# Altium schematic sheet [08] - Voltage Regulator.SchDoc("[08] - Voltage Regulator")
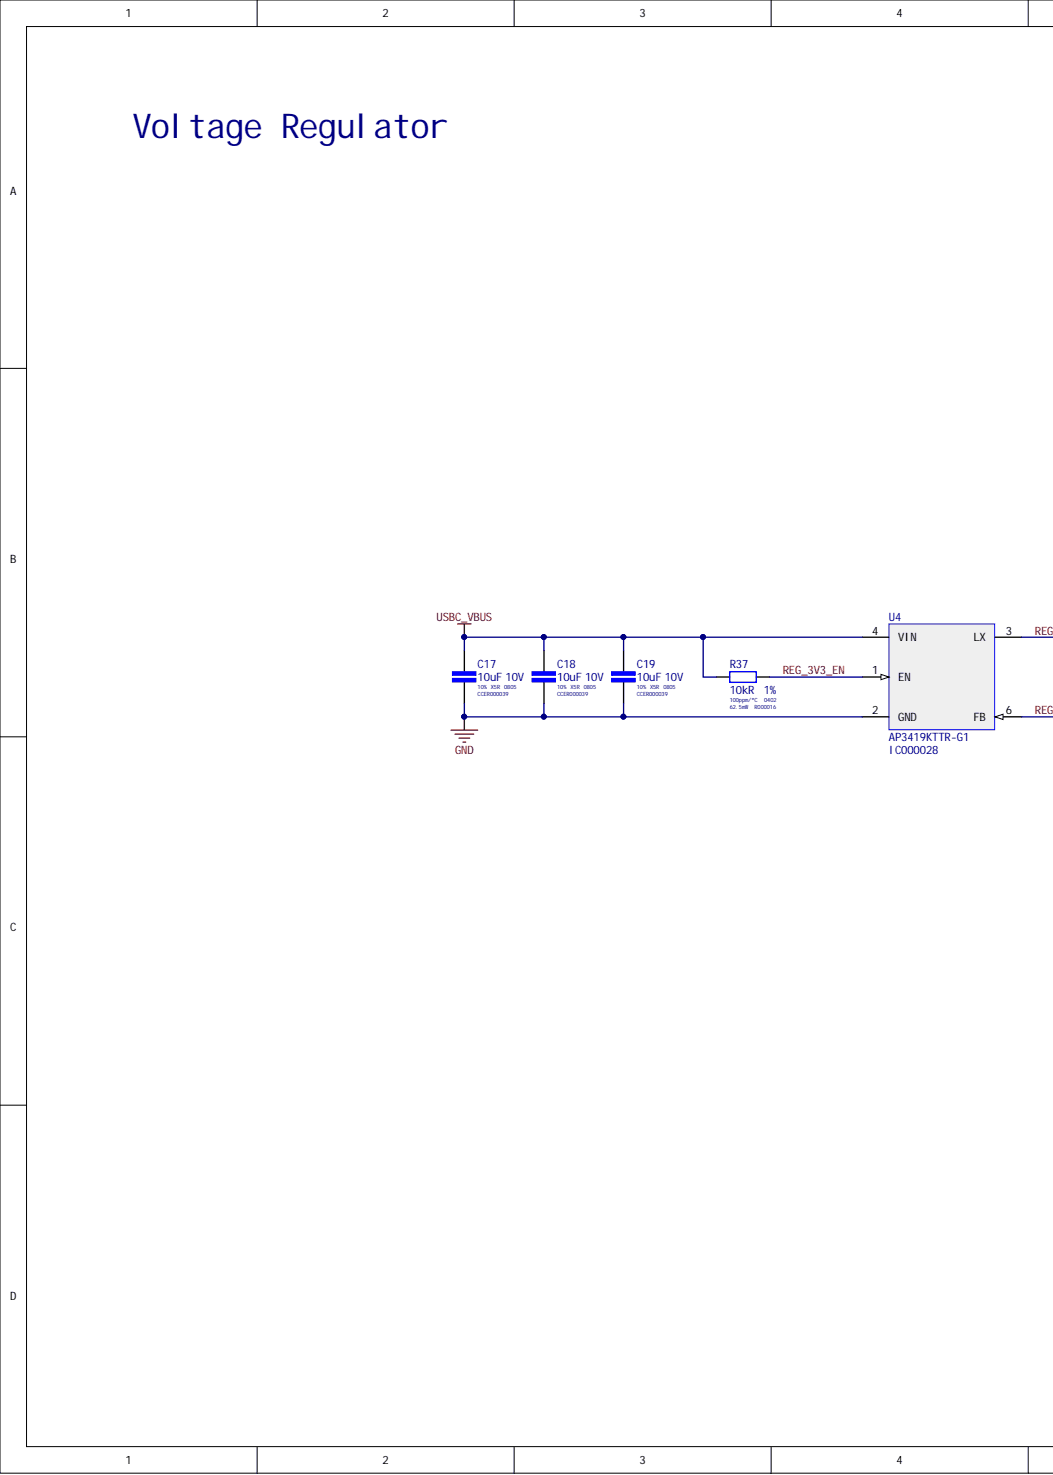
[diagram p0: [08] - Voltage Regulator.SchDoc, left]
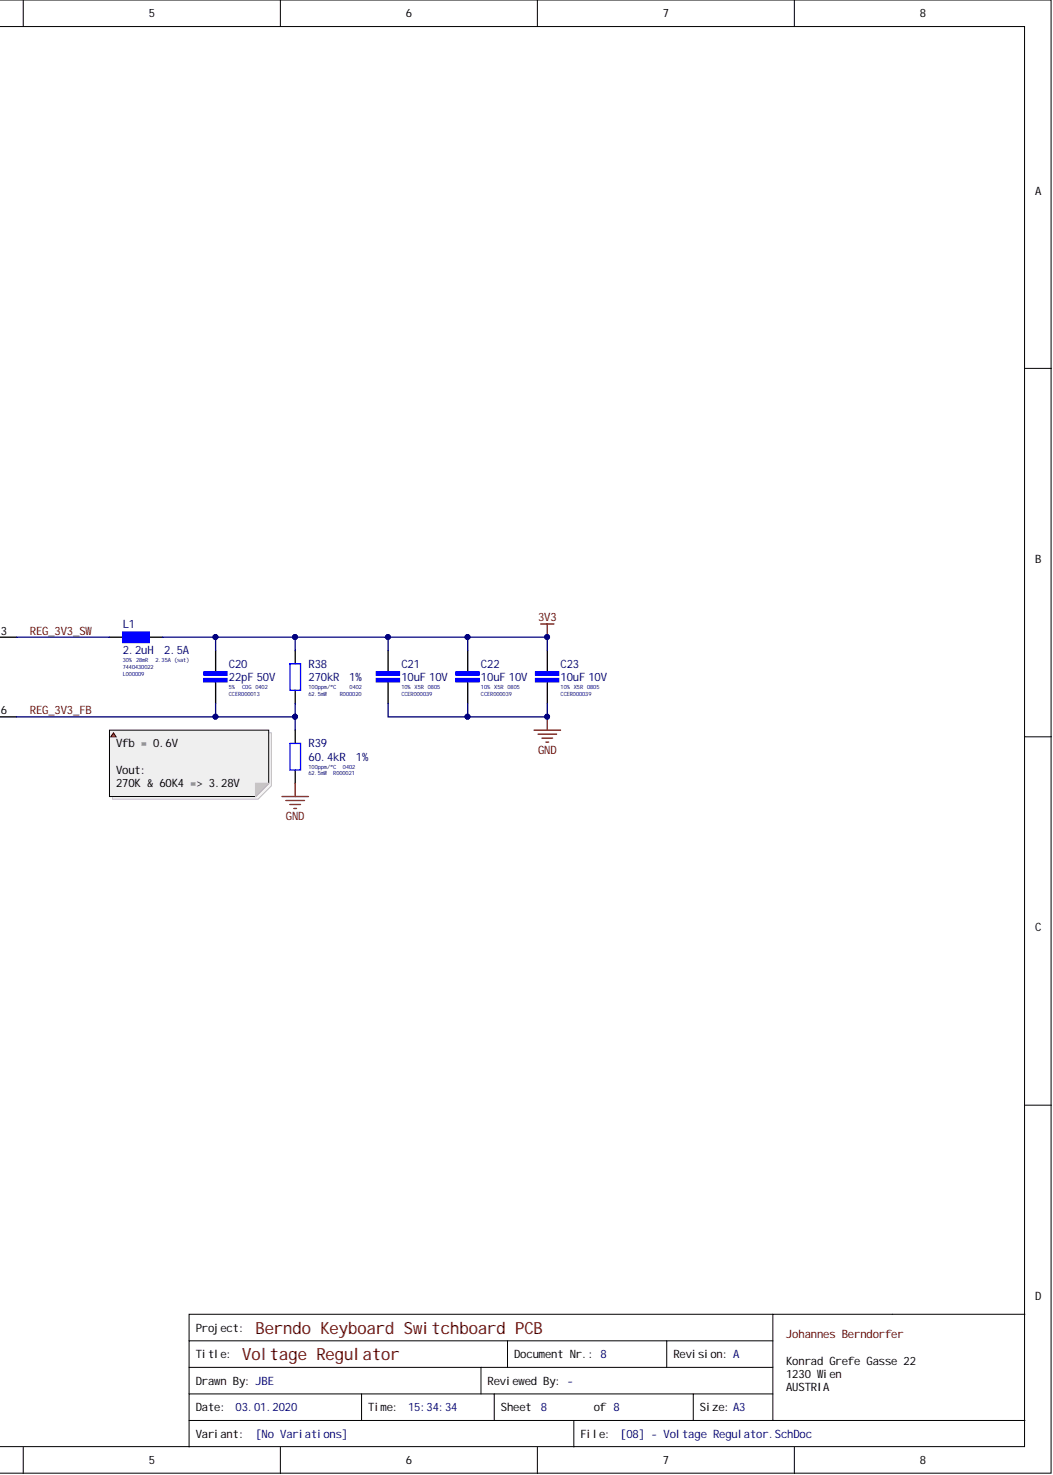
[diagram p1: [08] - Voltage Regulator.SchDoc, right]

## Parts
C17  10uF 10V  Tolerance: 10%  Internal Part Number: CCER000039  Dielectric: X5R  Capacitance: 10uF  [CAP-SMD-0805-1.35MM-IPC-MED]
C18  10uF 10V  Voltage Rating: 10V  Tolerance: 10%  Internal Part Number: CCER000039  Dielectric: X5R  Case Code (Imperial): 0805  Capacitance: 10uF  [CAP-SMD-0805-1.35MM-IPC-MED]
C19  10uF 10V  Voltage Rating: 10V  Tolerance: 10%  Internal Part Number: CCER000039  Dielectric: X5R  Case Code (Imperial): 0805  Capacitance: 10uF  [CAP-SMD-0805-1.35MM-IPC-MED]
C20  22pF 50V  Voltage Rating: 50V  Tolerance: 5%  Internal Part Number: CCER000013  Dielectric: C0G  Case Code (Imperial): 0402  Capacitance: 22pF  [CAP-SMD-0402-0.55MM-IPC-MED]
C21  10uF 10V  Voltage Rating: 10V  Tolerance: 10%  Internal Part Number: CCER000039  Dielectric: X5R  Capacitance: 10uF  [CAP-SMD-0805-1.35MM-IPC-MED]
C22  10uF 10V  Voltage Rating: 10V  Tolerance: 10%  Internal Part Number: CCER000039  Dielectric: X5R  Case Code (Imperial): 0805  Capacitance: 10uF  [CAP-SMD-0805-1.35MM-IPC-MED]
C23  10uF 10V  Voltage Rating: 10V  Tolerance: 10%  Internal Part Number: CCER000039  Dielectric: X5R  Case Code (Imperial): 0805  Capacitance: 10uF  [CAP-SMD-0805-1.35MM-IPC-MED]
L1  7440430022  Tolerance: 30%  Series Resistance: 28mR  RMS Current (Irms): 2.5A  Internal Part Number: L000009  Inductance: 2.2uH  [IND-SMD-7440430022-3MM]
R37  10kR 1%  Tolerance: 1%  Temperature Coefficient: 100ppm/°C  Resistance: 10kR  Power Rating: 62.5mW  Internal Part Number: R000016  Case Code (Imperial): 0402  [RES-SMD-0402-0.4MM-IPC-MED]
R38  Voltage Rating: 50V  Temperature Coefficient: 100ppm/°C  Resistance: 270kR  Max Power Dissipation: 62.5mW  Case Code (Imperial): 0402  [RES-SMD-0402-0.4MM-IPC-MED]  (hidden comment: RC0402FR-07270KL)
R39  Temperature Coefficient: 100ppm/°C  Resistance: 60.4kR  Power Rating: 62.5mW  [RES-SMD-0402-0.4MM-IPC-MED]  (hidden comment: RC0402FR-0760K4L)
U4  AP3419KTTR-G1  Internal Part Number: IC000028  [SOT-23-6-H1MM-DIODES-INC]

## Nets
3V3: C20.1 C21.1 C22.1 C23.1 L1.2 R38.1
GND: C17.2 C18.2 C19.2 C21.2 C22.2 C23.2 R39.2 U4.2(GND)
USBC_VBUS: C17.1 C18.1 C19.1 R37.1 U4.4(VIN)
REG_3V3_EN: R37.2 U4.1(EN)
REG_3V3_FB: C20.2 R38.2 R39.1 U4.6(FB)
REG_3V3_SW: L1.1 U4.3(LX)

## Library data of the parts
C17-C19 C21-C23 = CL21A106KPFNNNG: CAP CER 10U 10V X5R 10% SMD 0805 85C SAMSUNG; Width: 0.049inch; Voltage: 10V; Thickness: 0.053inch; Termination: Wraparound; Supplier Part Number 1: 1276-6456-1-ND; Supplier 1: Digi-Key; Stock 1: 884042; RoHS: Yes; Radiation Hardening: No; Pricing 1: 1=0,14000, 10=0,10000, 100=0,04690, 500=0,03290, 1000=0,02724 (USD); Packaging: Tape and Reel; Package Quantity: 3000; Mount: Surface Mount; Min Operating Temperature: -55°C; Max Operating Temperature: 85°C; Manufacturer Part Number 1: CL21A106KPFNNNG; Manufacturer 1: Samsung; Library Name: Altium_Lib_Standard.IntLib; Length: 2mm; Lead Free: Lead Free; Height: 1.25mm; Depth: 1.25mm; ComponentLink2URL: https://datasheet.ciiva.com/26936/getdatasheetpartid-640497-26936900.pdf?src-supplier=Verical; ComponentLink2Description: https://datasheet.ciiva.com/26936/getdatasheetpartid-640497-26936900.pdf?src-supplier=Verical; ComponentLink1URL: https://datasheet.ciiva.com/16428/cl21a106kpfnnng-16428896.pdf?src-supplier=Future+Electronics; ComponentLink1Description: https://datasheet.ciiva.com/16428/cl21a106kpfnnng-16428896.pdf?src-supplier=Future+Electronics; Component Kind: Standard; Case Code (Metric): 2012; Case/Package: 0805
  C17: Voltage Rating: 10V; Case Code (Imperial): 0805
  C21: Case Code (Imperial): 0805
C20 = CL05C220JB5NNNC: CAP CER 22P 50V C0G 5% SMD 0402 125C SAMSUNG; Temperature Coefficient: 300ppm/°C; Material: Ceramic; Width: 0.02inch; Voltage: 50V; Thickness: 0.022inch; Supplier Part Number 1: 1276-1116-1-ND; Supplier 1: Digi-Key; Stock 1: 1663917; RoHS: Yes; Radiation Hardening: No; Pricing 1: 1 = 0,10000, 10 = 0,03300, 100 = 0,01480, 500 = 0,01058, 1000 = 0,00831, 2500 = 0,00755, 5000 = 0,00695 (USD); Packaging: Tape and Reel; Package Quantity: 1; Mount: Surface Mount; Min Operating Temperature: -55°C; Max Operating Temperature: 125°C; Manufacturer Part Number: CL05C220JB5NNNC; Manufacturer: Samsung; Library Name: Altium_Lib_Standard.IntLib; Length: 1mm; Height: 0.5mm; Depth: 0.5mm; Contact Plating: Tin; ComponentLink4URL: https://datasheet.ciiva.com/26309/mlcc-catalogdownload2013-eng-26309032.pdf?src-supplier=Distrelec; ComponentLink4Description: https://datasheet.ciiva.com/26309/mlcc-catalogdownload2013-eng-26309032.pdf?src-supplier=Distrelec; ComponentLink3URL: https://datasheet.ciiva.com/16199/cl05b104kq5nnnc-16199080.pdf?src-supplier=Future+Electronics; ComponentLink3Description: https://datasheet.ciiva.com/16199/cl05b104kq5nnnc-16199080.pdf?src-supplier=Future+Electronics; ComponentLink2URL: https://datasheet.ciiva.com/29198/458663-da-01-en-vs-kondensator-0402-29198414.pdf?src-supplier=Conrad; ComponentLink2Description: https://datasheet.ciiva.com/29198/458663-da-01-en-vs-kondensator-0402-29198414.pdf?src-supplier=Conrad; ComponentLink1URL: https://datasheet.ciiva.com/15195/getdatasheetpartid-358448-15195679.pdf?src-supplier=Verical; ComponentLink1Description: https://datasheet.ciiva.com/15195/getdatasheetpartid-358448-15195679.pdf?src-supplier=Verical; Component Kind: Standard; Case Code (Metric): 1005; Case/Package: 0402
L1 = 7440430022: IND 2U2 2A5 28mR 30% SMD FERRITE WURTH; Manufacturer: Wurth Electronics; Test Frequency: 100kHz; Shielding: Shielded; Self Resonant Frequency: 100MHz; Saturation Current: 2.35A (sat); Width: 4.8mm; Weight: 0.009065oz; Termination: SMD/SMT; Supplier Part Number 1: 732-1095-1-ND; Supplier 1: Digi-Key; Stock 1: 3943; RoHS: Yes; REACH SVHC: Unknown; Pricing 1: 1 = 1,43000, 10 = 1,38900, 50 = 1,31900, 100 = 1,21500, 250 = 1,14600 (USD); Packaging: Tape and Reel; Package Quantity: 500; Mount: Surface Mount; Min Operating Temperature: -40°C; Max Operating Temperature: 125°C; Max DC Current: 2.5A; Manufacturer Part Number: 7440430022; Library Name: Altium_Lib_Standard.IntLib; Length: 4.8mm; Lead Free: Lead Free; Inductance Tolerance: 30%; Height - Seated (Max): 0.118inch; Height: 2.8mm; Depth: 4.8mm; DC Resistance (DCR): 28mR; Current Rating: 2.5A; Core Material: Ferrite; Composition: Wirewound; ComponentLink8URL: https://datasheet.ciiva.com/48690/001087187-da-01-ml-we-tpc-smd-speicherdrossel-7440430-de-en-48690886.pdf?src-supplier=Conrad; ComponentLink8Description: https://datasheet.ciiva.com/48690/001087187-da-01-ml-we-tpc-smd-speicherdrossel-7440430-de-en-48690886.pdf?src-supplier=Conrad; ComponentLink7URL: https://datasheet.ciiva.com/1075/7440430022-1075986.pdf?src-supplier=Digi-Key; ComponentLink7Description: https://datasheet.ciiva.com/1075/7440430022-1075986.pdf?src-supplier=Digi-Key; ComponentLink6URL: https://datasheet.ciiva.com/1075/7440430022-1075985.pdf?src-supplier=Digi-Key; ComponentLink6Description: https://datasheet.ciiva.com/1075/7440430022-1075985.pdf?src-supplier=Digi-Key; ComponentLink5URL: https://datasheet.ciiva.com/23782/1645803-23782392.pdf?src-supplier=Newark; ComponentLink5Description: https://datasheet.ciiva.com/23782/1645803-23782392.pdf?src-supplier=Newark; ComponentLink4URL: https://datasheet.ciiva.com/1075/7440430022-1075984.pdf?src-supplier=Digi-Key; ComponentLink4Description: https://datasheet.ciiva.com/1075/7440430022-1075984.pdf?src-supplier=Digi-Key; ComponentLink3URL: https://datasheet.ciiva.com/13277/7440430022-66848-13277005.pdf?src-supplier=Mouser; ComponentLink3Description: https://datasheet.ciiva.com/13277/7440430022-66848-13277005.pdf?src-supplier=Mouser; ComponentLink2URL: https://datasheet.ciiva.com/7754/1735426-7754427.pdf?src-supplier=Element14; ComponentLink2Description: https://datasheet.ciiva.com/7754/1735426-7754427.pdf?src-supplier=Element14; ComponentLink1URL: https://datasheet.ciiva.com/11803/0900766b80febc3e-11803289.pdf?src-supplier=RSComponents; ComponentLink1Description: https://datasheet.ciiva.com/11803/0900766b80febc3e-11803289.pdf?src-supplier=RSComponents; Component Kind: Standard; Case Code (Metric): 4848; Case Code (Imperial): 1919; Case/Package: 1919
R37 = RC0402FR-0710KL: RES 10K 1% 1/16W SMD 0402 YAEGO; Manufacturer: Yageo; Working Voltage: 50V; Width: 0.5mm; Voltage Rating (DC): 50V; Voltage Rating: 50V; Termination: SMD/SMT; Supplier Part Number 1: 311-10.0KLRCT-ND; Supplier 1: Digi-Key; Stock 1: 86154015; RoHS: Yes; REACH SVHC: No SVHC; Pricing 1: 1 = 0,10000, 10 = 0,01600, 100 = 0,00670, 1000 = 0,00301, 2500 = 0,00261, 5000 = 0,00215 (USD); Packaging: Tape and Reel; Package Quantity: 10000; Number of Terminations: 2; Number of Pins: 2; Min Operating Temperature: -55°C; Military Standard: Not; Max Operating Temperature: 155°C; Manufacturer Part Number: RC0402FR-0710KL; Library Name: Altium_Lib_Standard.IntLib; Length: 1mm; Lead Pitch: 0.2mm; Lead Free: Lead Free; Height: 0.32mm; Depth: 0.5mm; Composition: Thick Film; ComponentLink13URL: https://datasheet.ciiva.com/17070/pyu-rc0402-51-rohs-l-6-r-17070335.pdf?src-supplier=Future+Electronics; ComponentLink13Description: https://datasheet.ciiva.com/17070/pyu-rc0402-51-rohs-l-6-r-17070335.pdf?src-supplier=Future+Electronics; ComponentLink12URL: https://datasheet.ciiva.com/7849/1018594-7849967.pdf?src-supplier=Element14; ComponentLink12Description: https://datasheet.ciiva.com/7849/1018594-7849967.pdf?src-supplier=Element14; ComponentLink11URL: https://datasheet.ciiva.com/7849/1018594-7849966.pdf?src-supplier=Element14; ComponentLink11Description: https://datasheet.ciiva.com/7849/1018594-7849966.pdf?src-supplier=Element14; ComponentLink10URL: https://datasheet.ciiva.com/24070/pyu-rc0402-51-rohs-l-6-r-8721-24070077.pdf?src-supplier=Mouser; ComponentLink10Description: https://datasheet.ciiva.com/24070/pyu-rc0402-51-rohs-l-6-r-8721-24070077.pdf?src-supplier=Mouser; ComponentLink9URL: https://datasheet.ciiva.com/15189/getdatasheetpartid-329253-15189431.pdf?src-supplier=Verical; ComponentLink9Description: https://datasheet.ciiva.com/15189/getdatasheetpartid-329253-15189431.pdf?src-supplier=Verical; ComponentLink8URL: https://datasheet.ciiva.com/11819/0900766b80c66297-11819487.pdf?src-supplier=RSComponents; ComponentLink8Description: https://datasheet.ciiva.com/11819/0900766b80c66297-11819487.pdf?src-supplier=RSComponents; ComponentLink7URL: https://datasheet.ciiva.com/7983/1018594-7983486.pdf?src-supplier=Element14; ComponentLink7Description: https://datasheet.ciiva.com/7983/1018594-7983486.pdf?src-supplier=Element14; ComponentLink6URL: https://datasheet.ciiva.com/12667/pyu-rc0402-51-rohs-l-6-r-12667067.pdf?src-supplier=Mouser; ComponentLink6Description: https://datasheet.ciiva.com/12667/pyu-rc0402-51-rohs-l-6-r-12667067.pdf?src-supplier=Mouser; ComponentLink5URL: https://datasheet.ciiva.com/22747/1018594-22747512.pdf?src-supplier=Element14; ComponentLink5Description: https://datasheet.ciiva.com/22747/1018594-22747512.pdf?src-supplier=Element14; ComponentLink4URL: https://datasheet.ciiva.com/7849/1784430-7849815.pdf?src-supplier=Element14; ComponentLink4Description: https://datasheet.ciiva.com/7849/1784430-7849815.pdf?src-supplier=Element14; ComponentLink3URL: https://datasheet.ciiva.com/7849/1686128-7849814.pdf?src-supplier=Element14; ComponentLink3Description: https://datasheet.ciiva.com/7849/1686128-7849814.pdf?src-supplier=Element14; ComponentLink2URL: https://datasheet.ciiva.com/7849/1784430-7849813.pdf?src-supplier=Element14; ComponentLink2Description: https://datasheet.ciiva.com/7849/1784430-7849813.pdf?src-supplier=Element14; ComponentLink1URL: https://datasheet.ciiva.com/7660/38568-7660787.pdf?src-supplier=Element14; ComponentLink1Description: https://datasheet.ciiva.com/7660/38568-7660787.pdf?src-supplier=Element14; Component Kind: Standard; Case Code (Metric): 1005; Case/Package: 0402
R38 = RC0402FR-07270KL: RES 270K 1% 1/16W SMD 0402 YAEGO; Manufacturer: Yageo; Internal Part Number: R000020; Power Rating: 63mW; Width: 0.5mm; Weight: 2.3E-05oz; Tolerance: 1%; Termination: SMD/SMT; Supplier Part Number 1: 311-270KLRCT-ND; Supplier 1: Digi-Key; Stock 1: 339624; RoHS: Yes; REACH SVHC: Unknown; Pricing 1: 1 = 0,10000, 10 = 0,01600, 100 = 0,00640, 1000 = 0,00289, 2500 = 0,00251, 5000 = 0,00207 (USD); Packaging: Tape and Reel; Package Quantity: 1; Number of Terminations: 2; Min Operating Temperature: -55°C; Military Standard: Not; Max Operating Temperature: 155°C; Manufacturer Part Number: RC0402FR-07270KL; Library Name: Altium_Lib_Standard.IntLib; Length: 1mm; Lead Free: Lead Free; Height: 0.016inch; Features: Moisture Resistant; Depth: 0.5mm; Composition: Thick Film; ComponentLink5URL: https://datasheet.ciiva.com/2491/pyu-r-int-thick-7-2491340.pdf?src-supplier=Digi-Key; ComponentLink5Description: https://datasheet.ciiva.com/2491/pyu-r-int-thick-7-2491340.pdf?src-supplier=Digi-Key; ComponentLink4URL: https://datasheet.ciiva.com/2491/pyu-r-int-thick-7-2491339.pdf?src-supplier=Digi-Key; ComponentLink4Description: https://datasheet.ciiva.com/2491/pyu-r-int-thick-7-2491339.pdf?src-supplier=Digi-Key; ComponentLink3URL: https://datasheet.ciiva.com/16772/pyu-rc0402-51-rohs-l-6-r-16772665.pdf?src-supplier=Future+Electronics; ComponentLink3Description: https://datasheet.ciiva.com/16772/pyu-rc0402-51-rohs-l-6-r-16772665.pdf?src-supplier=Future+Electronics; ComponentLink2URL: https://datasheet.ciiva.com/15189/getdatasheetpartid-327811-15189397.pdf?src-supplier=Verical; ComponentLink2Description: https://datasheet.ciiva.com/15189/getdatasheetpartid-327811-15189397.pdf?src-supplier=Verical; ComponentLink1URL: https://datasheet.ciiva.com/2491/pyu-r-int-thick-7-2491341.pdf?src-supplier=Digi-Key; ComponentLink1Description: https://datasheet.ciiva.com/2491/pyu-r-int-thick-7-2491341.pdf?src-supplier=Digi-Key; Component Kind: Standard; Case Code (Metric): 1005; Case/Package: 0402
R39 = RC0402FR-0760K4L: RES 60K4 1% 1/16W SMD 0402 YAEGO; Manufacturer: Yageo; Internal Part Number: R000021; Voltage Rating (DC): 50V; Width: 500um; Voltage Rating: 50V; Tolerance: 1%; Termination: SMD/SMT; Supplier Part Number 1: 311-60.4KLRCT-ND; Supplier 1: Digi-Key; Stock 1: 969860; RoHS: Yes; Pricing 1: 1 = 0,10000, 10 = 0,01600, 100 = 0,00640, 1000 = 0,00289, 2500 = 0,00251, 5000 = 0,00207 (USD); Packaging: Tape and Reel; Min Operating Temperature: -55°C; Military Standard: Not; Max Operating Temperature: 155°C; Manufacturer Part Number: RC0402FR-0760K4L; Library Name: Altium_Lib_Standard.IntLib; Length: 1mm; Lead Free: Lead Free; Depth: 0.5mm; Contact Plating: Tin,Matte; Composition: Thick Film; ComponentLink4URL: https://datasheet.ciiva.com/8888/pyu-rc0402-51-rohs-l-6-8888622.pdf?src-supplier=Avnet; ComponentLink4Description: https://datasheet.ciiva.com/8888/pyu-rc0402-51-rohs-l-6-8888622.pdf?src-supplier=Avnet; ComponentLink3URL: https://datasheet.ciiva.com/16867/pyu-rc0402-51-rohs-l-6-16867357.pdf?src-supplier=Avnet+Europe; ComponentLink3Description: https://datasheet.ciiva.com/16867/pyu-rc0402-51-rohs-l-6-16867357.pdf?src-supplier=Avnet+Europe; ComponentLink2URL: https://datasheet.ciiva.com/28398/pyu-rc0402-51-rohs-l-6-28398086.pdf?src-supplier=Avnet+Europe; ComponentLink2Description: https://datasheet.ciiva.com/28398/pyu-rc0402-51-rohs-l-6-28398086.pdf?src-supplier=Avnet+Europe; ComponentLink1URL: https://datasheet.ciiva.com/17068/pyu-rc0402-51-rohs-l-6-r-17068614.pdf?src-supplier=Future+Electronics; ComponentLink1Description: https://datasheet.ciiva.com/17068/pyu-rc0402-51-rohs-l-6-r-17068614.pdf?src-supplier=Future+Electronics; Component Kind: Standard; Case Code (Metric): 1005; Case Code (Imperial): 0402; Case/Package: 0402
U4 = AP3419KTTR-G1: IC REGULATOR BUCK 2A SYNC 1MHZ SOT-23-6; Manufacturer: Diodes; Synchronous Rectifier: Yes; Output Type: Adjustable; Output Configuration: Positive; Number of Outputs: 1; Min Output Voltage: 600mV; Min Input Voltage: 2.7V; Max Output Voltage: 5.5V; Switching Frequency: 1MHz; Supplier Part Number 1: AP3419KTTR-G1DICT-ND; Supplier 1: Digi-Key; Stock 1: 72992; RoHS: Yes; Pricing 1: 1 = 0,42000, 10 = 0,33900, 100 = 0,23070, 500 = 0,17304, 1000 = 0,12978 (USD); Packaging: Tape and Reel; Output Current: 2A; Mount: Surface Mount; Min Operating Temperature: -40°C; Max Operating Temperature: 85°C; Max Input Voltage: 5.5V; Manufacturer Part Number: AP3419KTTR-G1; Library Name: Altium_Lib_Standard.IntLib; ComponentLink1URL: https://www.diodes.com/assets/Datasheets/AP3419.pdf?src-supplier=Digi-Key; ComponentLink1Description: https://www.diodes.com/assets/Datasheets/AP3419.pdf?src-supplier=Digi-Key; Component Kind: Standard; Case/Package: SOT-23-6
